# Altium schematic sheet 03-ACI-Drive-Unit-HV00.00.SchDoc(03-ACI-Drive-Unit-HV00.00)
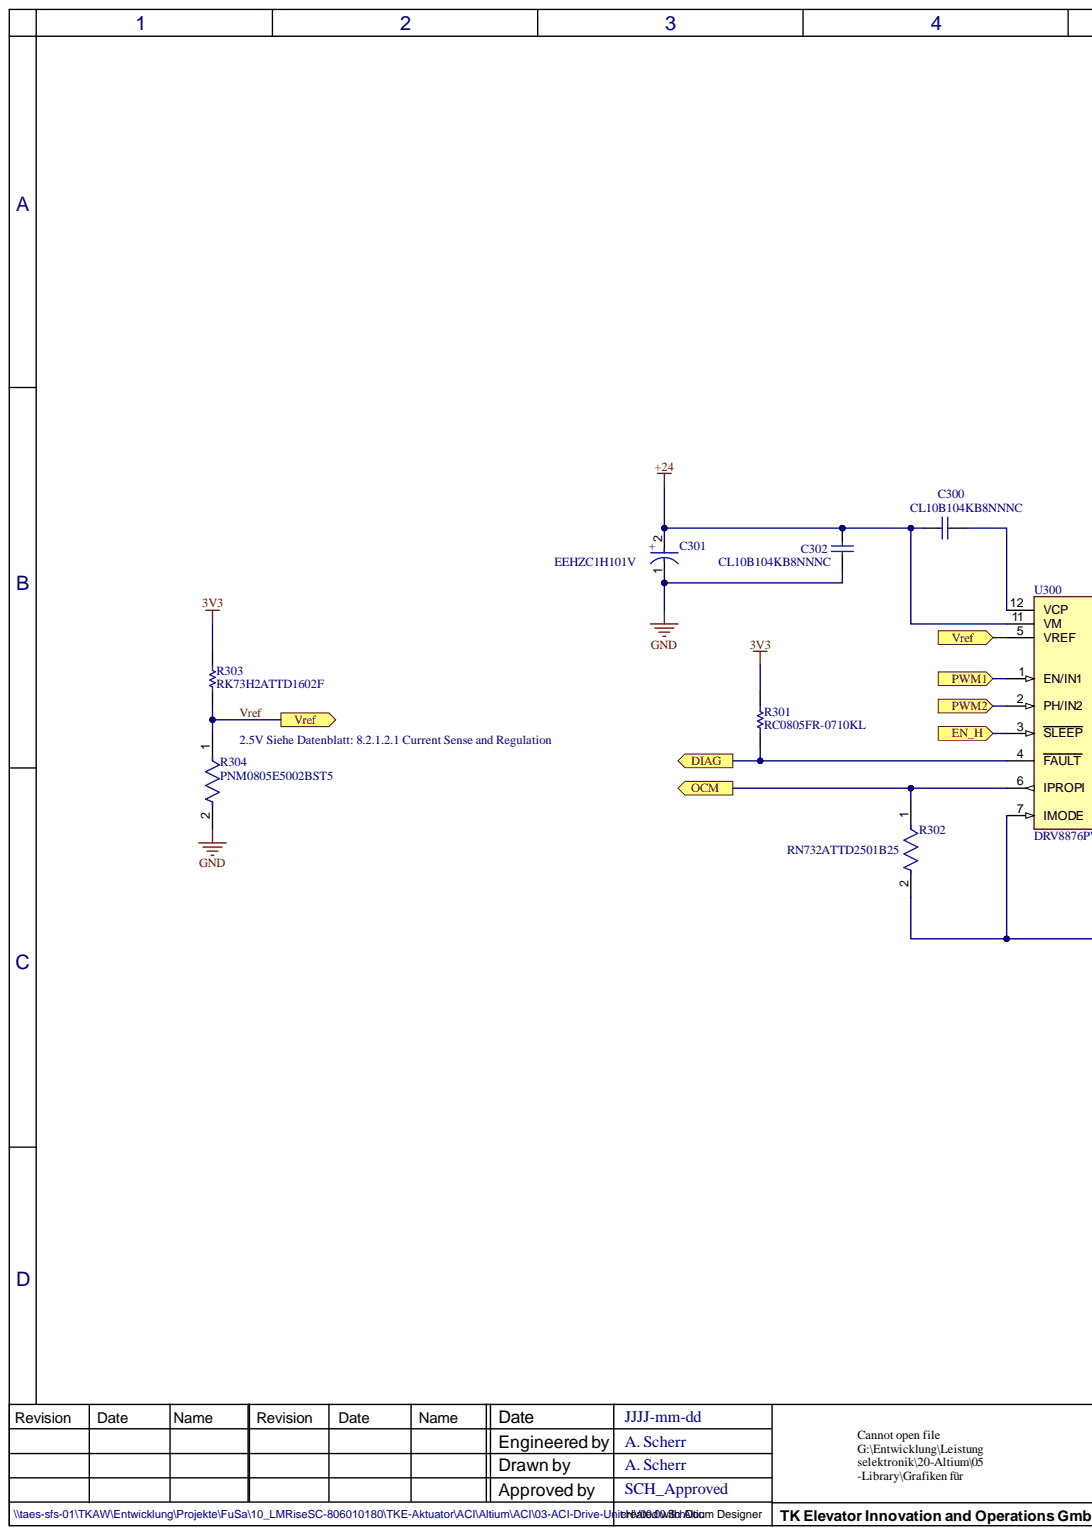
[diagram p0: 03-ACI-Drive-Unit-HV00.00.SchDoc, left]
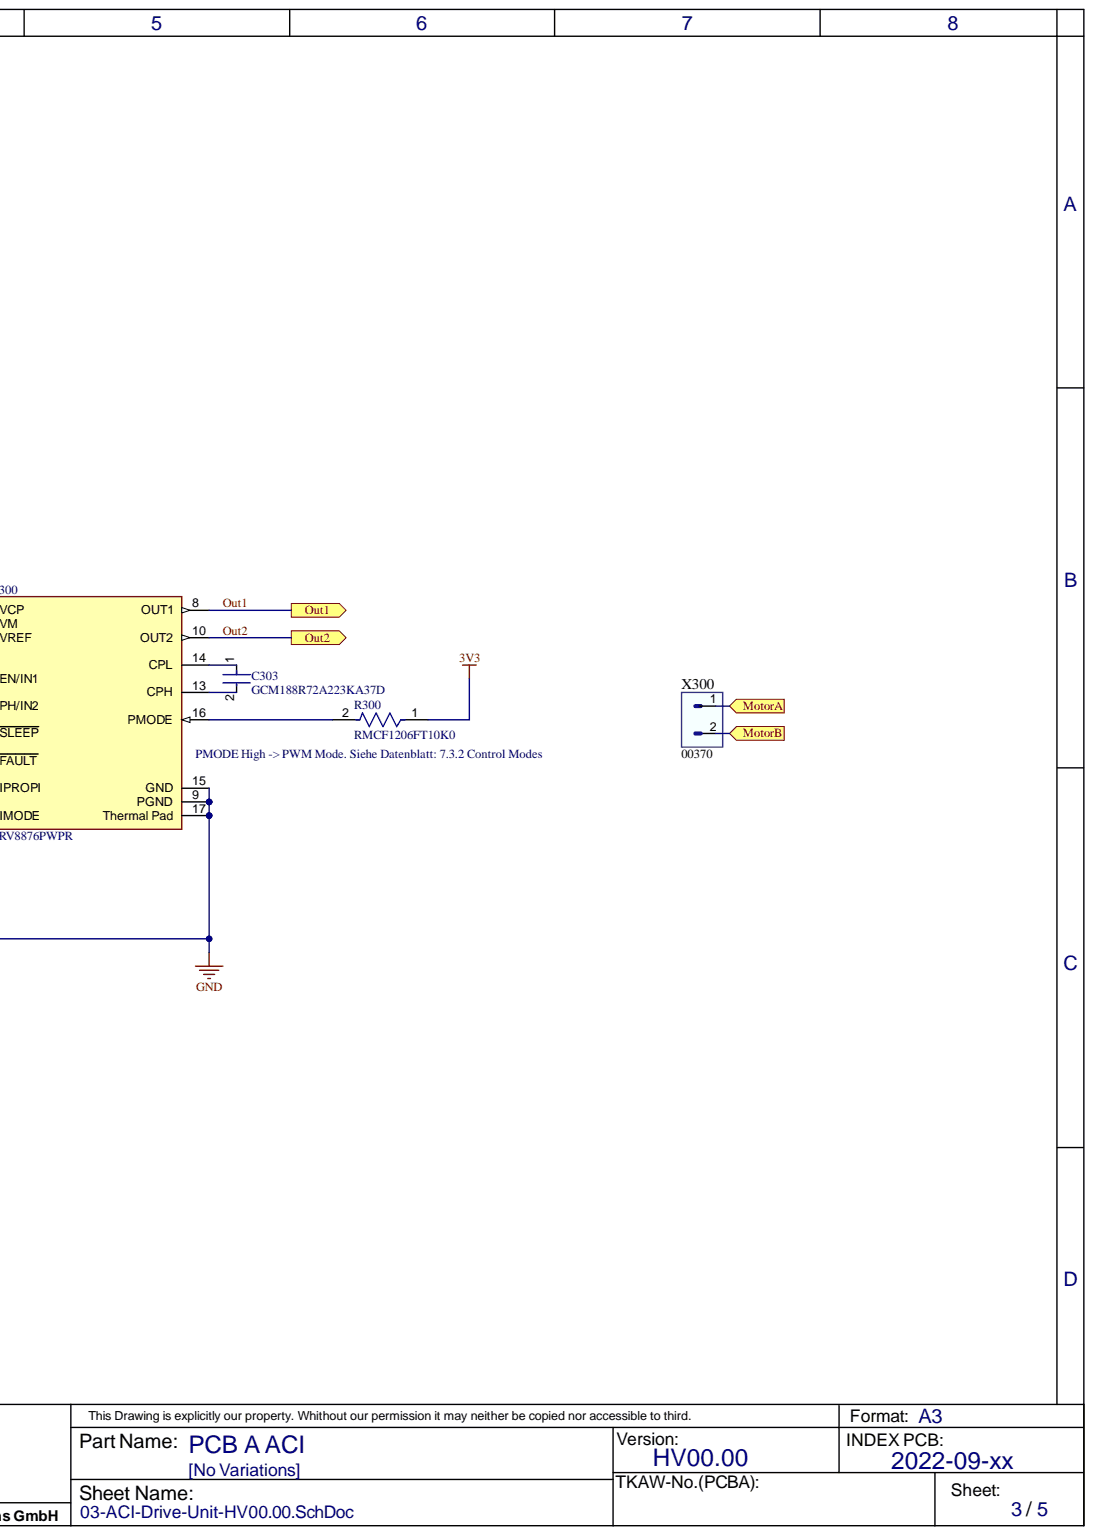
[diagram p1: 03-ACI-Drive-Unit-HV00.00.SchDoc, right]

## Parts
C300  CL10B104KB8NNNC  [SAMS-C0603-2_M]
C301  EEHZC1H101V  [FP-EEH-G-V-MFG]
C302  CL10B104KB8NNNC  [SAMS-C0603-2_M]
C303  GCM188R72A223KA37D  [FP-GCM188-0_1-MFG]
R300  RMCF1206FT10K0  [FP-RMCF1206-MFG]
R301  RC0805FR-0710KL  [RESC2013X60X35NL10T20]
R302  RN732ATTD2501B25  [FP-RN732A-IPC_B]
R303  RK73H2ATTD1602F  [RESC2013X60X30LL20T20]
R304  PNM0805E5002BST5  [FP-PNM0805-MFG]
U300  DRV8876PWPR  [FP-PWP0016C-MFG]
X300  00370  [CON THR_Combicon_male_2pol_str_pitch_5.08mm]

## Ports
DIAG, EN_H, MotorA, MotorB, OCM, Out1, Out2, PWM1, PWM2, Vref

## Nets
3V3: R300.1 R301.1 R303.1
+24: C300.1 C301.2 C302.1 U300.11(VM)
GND: C301.1 C302.2 R302.2 R304.2 U300.7(IMODE) U300.9(PGND) U300.15(GND) U300.17(Thermal_Pad)
DIAG: R301.2 U300.4(FAULT) ; port DIAG
EN_H: U300.3(SLEEP) ; port EN_H
MotorA: X300.1 ; port MotorA
MotorB: X300.2 ; port MotorB
OCM: R302.1 U300.6(IPROPI) ; port OCM
Out1: U300.8(OUT1) ; port Out1
Out2: U300.10(OUT2) ; port Out2
PWM1: U300.1(EN/IN1) ; port PWM1
PWM2: U300.2(PH/IN2) ; port PWM2
Vref: R303.2 R304.1 U300.5(VREF) ; port Vref
NetC300_2: C300.2 U300.12(VCP)
NetC303_1: C303.1 U300.14(CPL)
NetC303_2: C303.2 U300.13(CPH)
NetR300_2: R300.2 U300.16(PMODE)

## Library data of the parts
C300 C302 = CMP-2000-05332-1: Multi-layer Ceramic Capacitor, -55 to 125 degC, 2-Pin SMD (0603), RoHS, Tape and Reel; Manufacturer: Samsung Electro-Mechanics; Capacitance: 0.1µF; Dielectric: X7R; Rated Voltage: 50V; Tolerance (%): ±10%; Case Size/Dimension: 0603; Component Kind: Standard; Datasheet URL: https://datasheet.ciiva.com/29198/456651-da-01-en-vs-kondensator-0605-29198839.pdf; Datasheet Version: Nov-10; Library Name: Altium Content Vault; Manufacturer URL: http://www.samsungsem.com/global/index.jsp
C301 = CMP-05428-000239-1: Aluminum Hybrid Polymer Capacitors 100uF 20% 50V Life 4000Hours AEC-Q200 RADIAL SMT; Component Kind: Standard; Library Name: Altium Content Vault; RoHS Compliant: Yes
C303 = CMP-2006-02397-2: Ceramic Capacitor for Automotive 22nF  ±10% 100VDC X7R 0603 Paper T/R; Voltage: 100V; Capacitance: 22nF; Case Code (Imperial): 0603; Case Code (Metric): 1608; Case/Package: 0603; Component Kind: Standard; Depth: 0.8mm; Dielectric: X7R; Height: 0.8mm; Lead Free: Lead Free; Length: 1.6mm; Library Name: Altium Content Vault; Max Operating Temperature: 125°C; Min Operating Temperature: -55°C; Mount: Surface Mount; Package Quantity: 4000; Packaging: Tape and Reel; Radiation Hardening: No; Ratings: AEC-Q200; REACH SVHC: No SVHC; Termination: SMD/SMT; Thickness: 0.035inch; Tolerance: 10%; Voltage Rating: 100V; Voltage Rating (DC): 100V; Width: 0.031inch
R300 = CMP-26527-000151-1: 10kΩ ±1% 0.25W 1206 Thick Film Chip Resistor AEC-Q200 compliant; Max Power Dissipation: 250mW; Resistance: 10kR; Temperature Coefficient: 100ppm/°C; Case Code (Imperial): 1206; Case Code (Metric): 3216; Case/Package: 1206; Component Kind: Standard; Construction: Rectangular; Contact Plating: Tin; Depth: 1.6mm; Features: Automotive AEC-Q200; Height: 0.028inch; Lead Free: Lead Free; Length: 3.2mm; Library Name: Altium Content Vault; Max Operating Temperature: 155°C; Military Standard: Not; Min Operating Temperature: -55°C; Mount: Surface Mount; Number of Terminations: 2; Packaging: Tape and Reel; Power Rating: 250mW; Radiation Hardening: No; Resistor Type: Thick Film; RoHS Compliant: Yes; Series: RMCF; Size Code: 1206; Tolerance: 1%; Width: 0.063inch
R301 = CMP-1659-00013-4: Chip Resistor, 10 KOhm, +/- 1%, 0.125 W, -55 to 155 degC, 0805 (2012 Metric), RoHS, Tape and Reel; Manufacturer: Yageo; Voltage Rating: 150 V; Component Kind: Standard; ComponentLink1Description: Manufacturer URL; ComponentLink1URL: http://www.yageo.com/; ComponentLink2Description: Datasheet; ComponentLink2URL: http://www.yageo.com/documents/recent/PYu-RC_Group_51_RoHS_L_04.pdf; DatasheetVersion: Rev. 4, 02/2016; Height: 0.5 mm; Length: 2 mm; Library Name: Altium Content Vault; Max Operating Temperature: 155 degC; Min Operating Temperature: -55 degC; Mount: Surface Mount; Mounting Technology: Surface Mount; Package Quantity: 5000; PackageDescription: 2-Pin Surface Mounted Device, Body 2 x 1.25 mm; PackageReference: 0805; Packaging: Tape and Reel; Pins: 2; REACH SVHC: No SVHC; RoHSCompliant: true
R302 = CMP-04015-065178-1: 2.5kΩ ±0.1% 0.1W, 1/10W Chip Resistor 0805 (2012 Metric) Moisture Resistant Thin Film; Power Rating: 100mW; Resistance: 2.5kR; Temperature Coefficient: 25ppm/°C; Voltage Rating: 100V; Case Code (Imperial): 0805; Case Code (Metric): 2012; Case/Package: 0805; Component Kind: Standard; Library Name: Altium Content Vault; Max Operating Temperature: 125°C; Min Operating Temperature: -55°C; Packaging: Tape and Reel; Termination: SMD/SMT; Tolerance: 0.1%
R303 = CMP-2001-02111-1: Manufacturer: KOA Speer; Component Kind: Standard; Library Name: Altium Content Vault; Part Number: RK73H2ATTD1602F
R304 = CMP-02407-012955-1: RES SMD 50K OHM 0.1% 1/5W 0805; Max Power Dissipation: 200mW; Resistance: 50kR; Temperature Coefficient: 25ppm/°C; Voltage Rating: 100V; Case Code (Imperial): 0805; Case Code (Metric): 2012; Case/Package: 0805; Component Kind: Standard; Features: Moisture Resistant; Height: 0.015inch; Length: 0.08inch; Library Name: Altium Content Vault; Max Operating Temperature: 155°C; Min Operating Temperature: -55°C; Number of Terminations: 2; Packaging: Tape and Reel; Power Rating: 200mW; Resistance Tolerance: 0.1%; Resistor Type: Thin Film; RoHS Compliant: Yes; Series: PNM; Termination: SMD/SMT; Tolerance: 0.1%; Voltage Rating (DC): 100V; Width: 0.05inch
U300 = CMP-04915-000007-1: PWR MGMT MOTOR/FAN DRIVER; Component Kind: Standard; Library Name: Altium Content Vault
X300 = CMP-015-000084-1: PartCategory: 036-Connector THR; PartDescription: Connector THR Combicon male 2pol str pitch 5.08; PartValue: 2pol str pitch 5.08mm; PartVariation: male; BOMSortNo: 2 03601 050802; created/last change: TKEGermany/Glück; manu no1: Phoenix; order no1: CCVA2,5/2-G-5,08 P26 THR  NR.1955853; Pitch/Package: 5.08mm; TKAW No: 9950 001 1185; Component Kind: Standard; Description: 00370; Ident: 00370; PartType: Combicon; Library Name: TK Elevator
